# Altium schematic sheet LM25148_eval_BRD.SchDoc
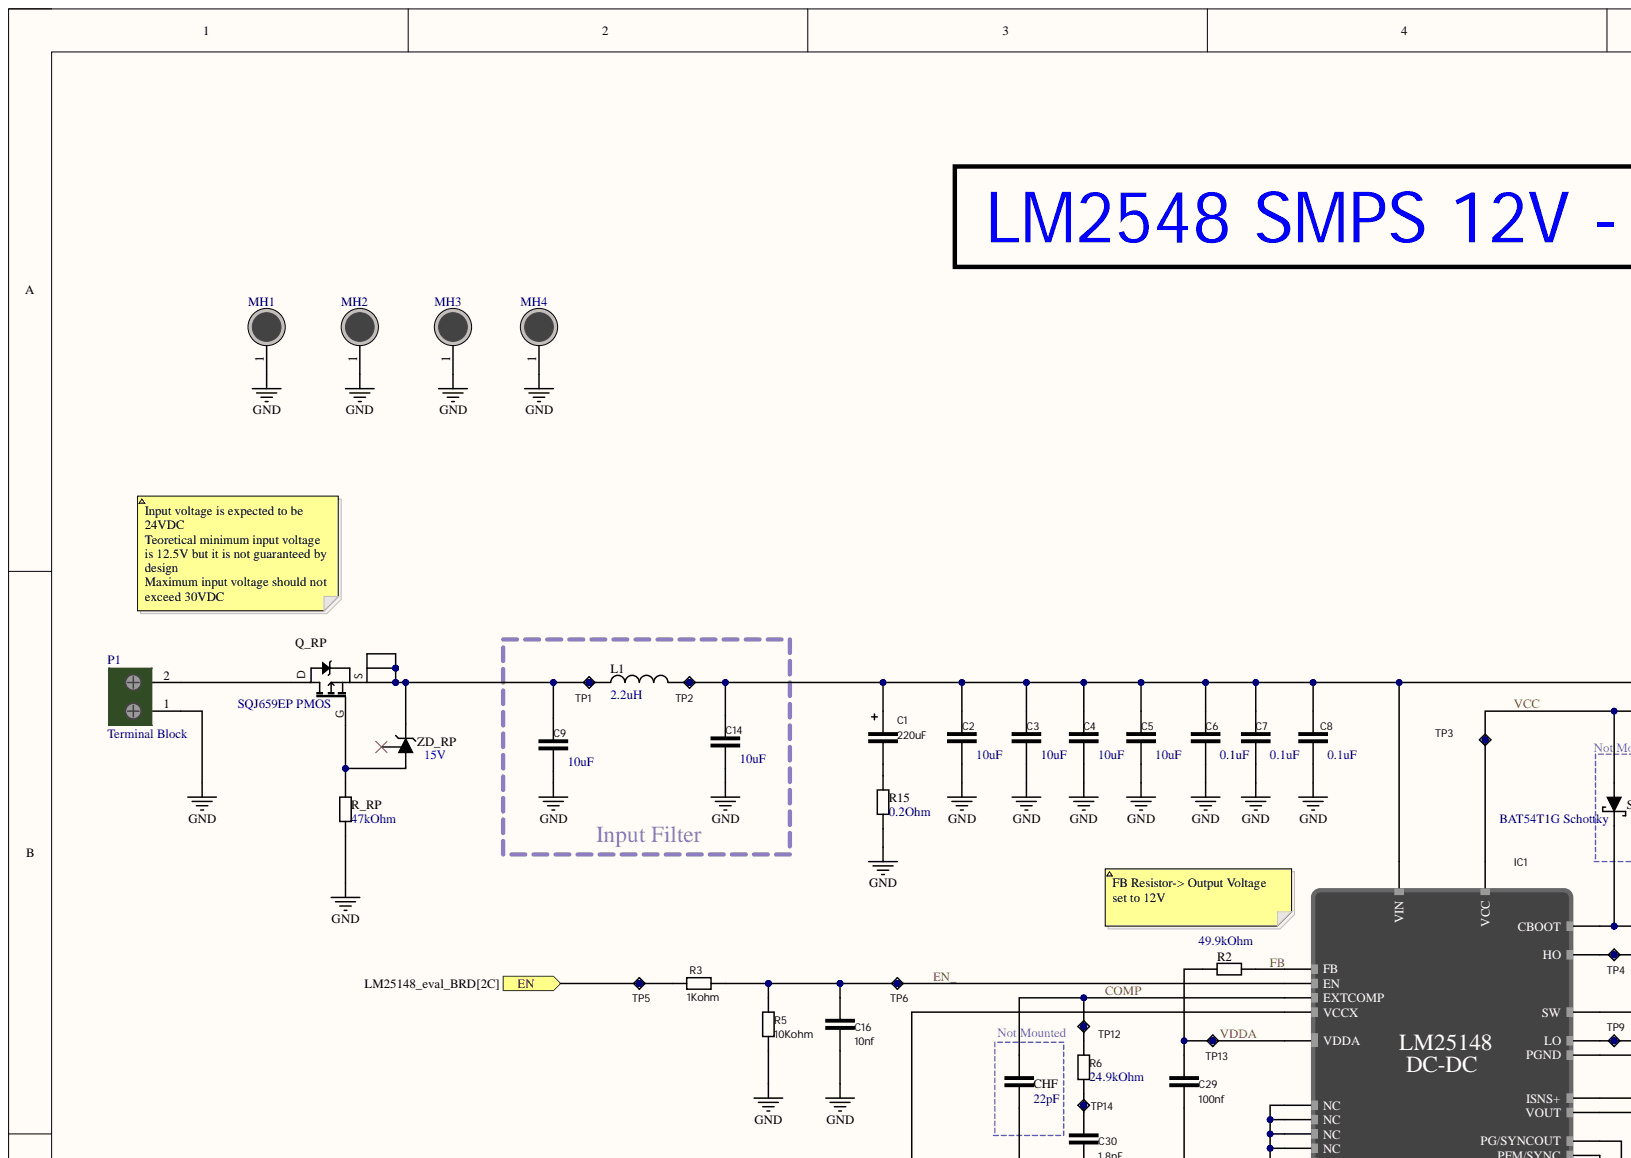
[diagram p0: LM25148_eval_BRD.SchDoc, top left]
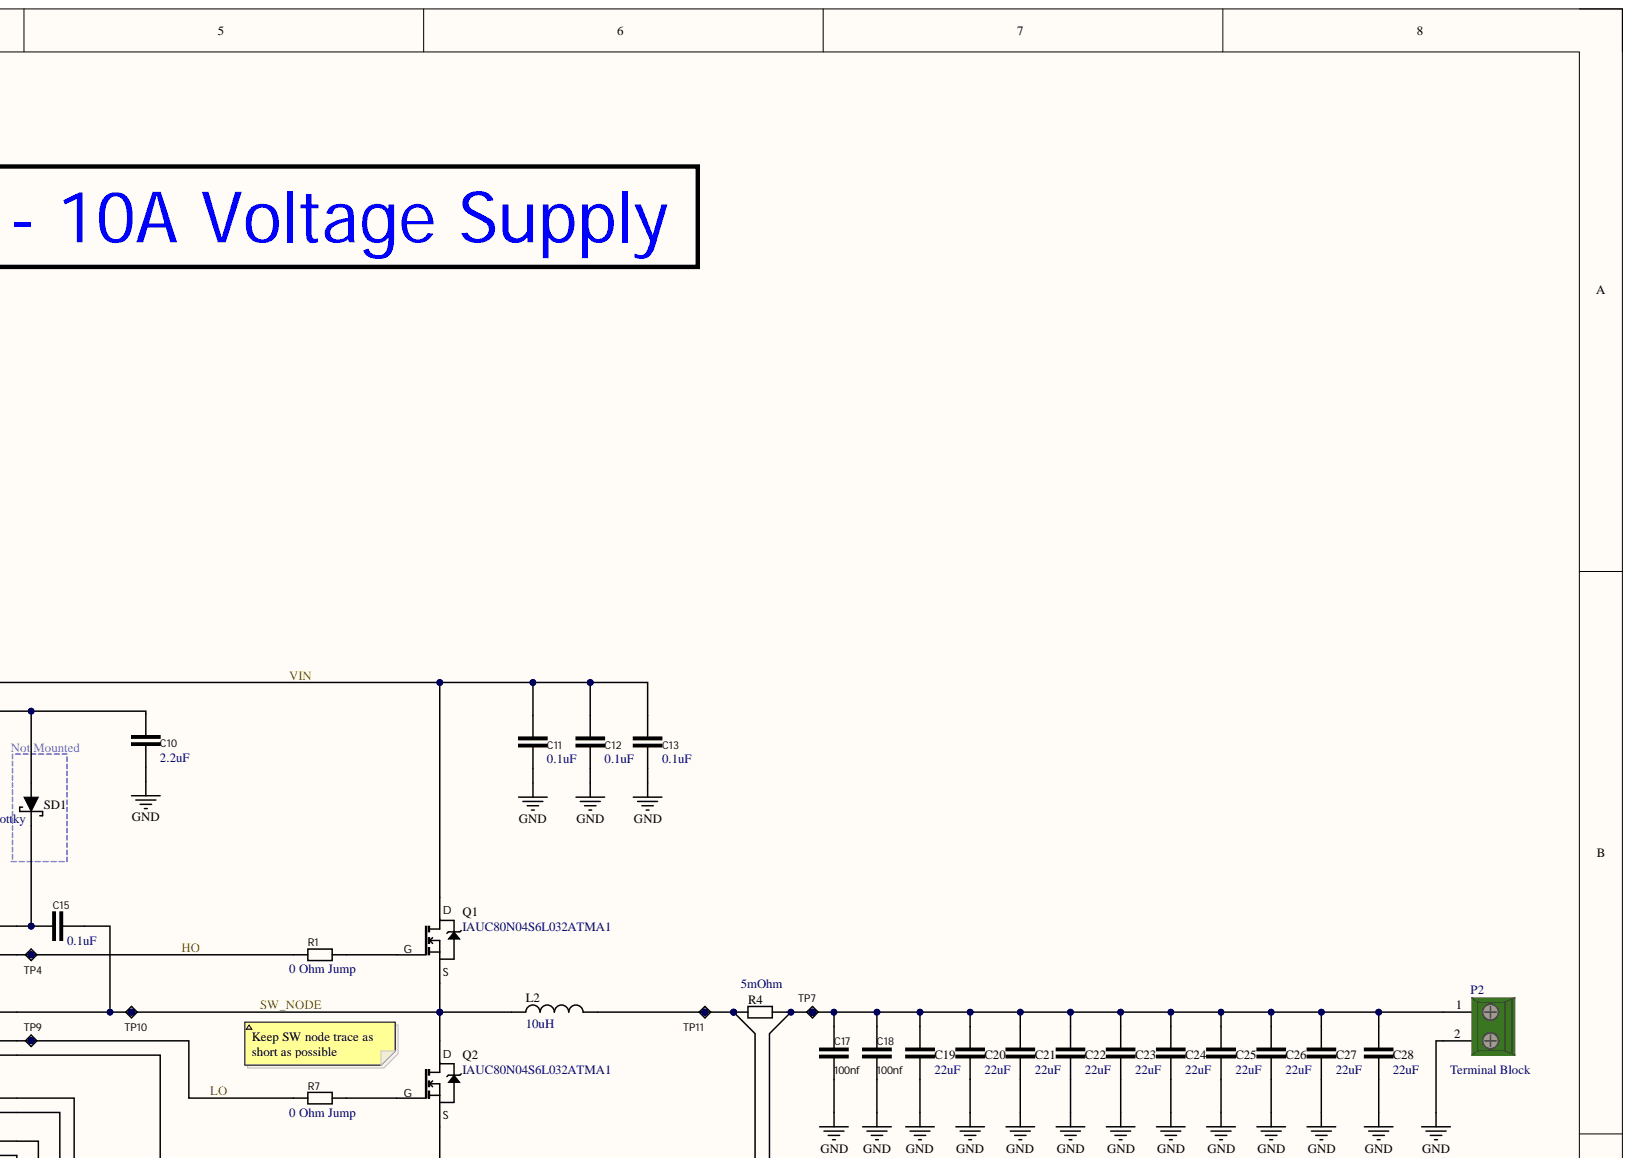
[diagram p1: LM25148_eval_BRD.SchDoc, top right]
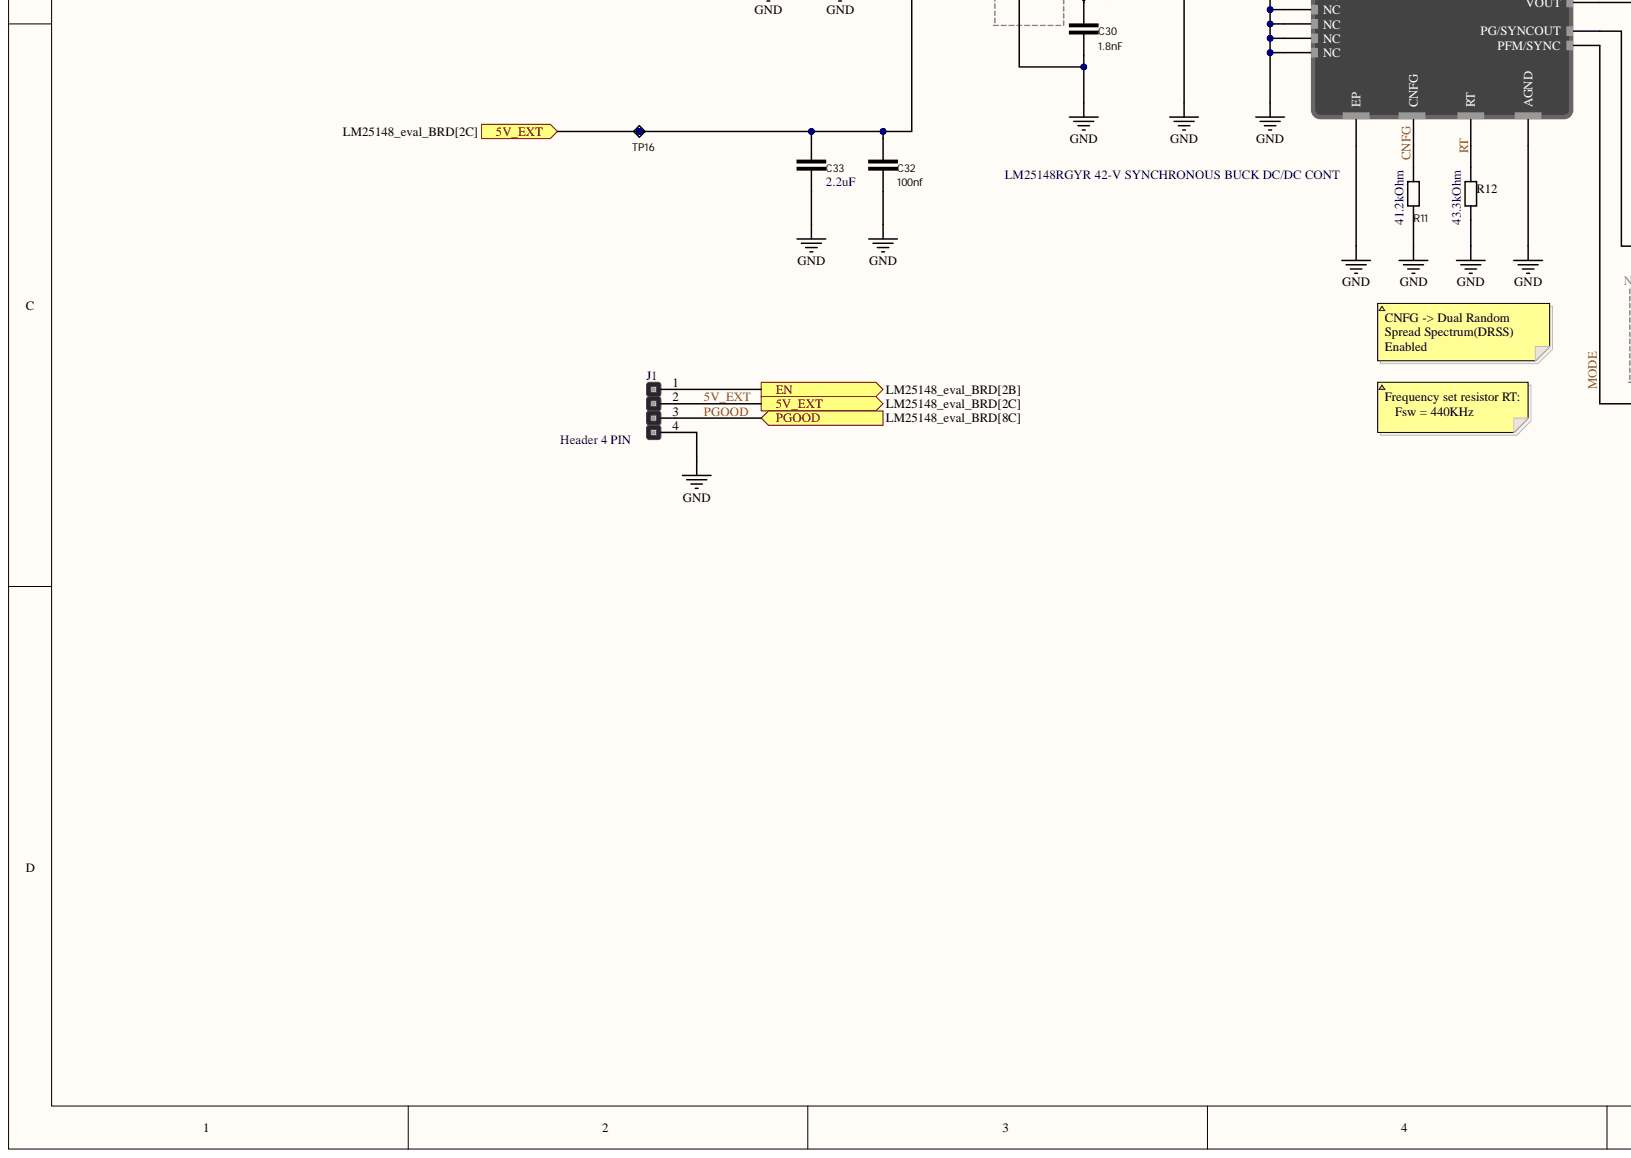
[diagram p2: LM25148_eval_BRD.SchDoc, bottom left]
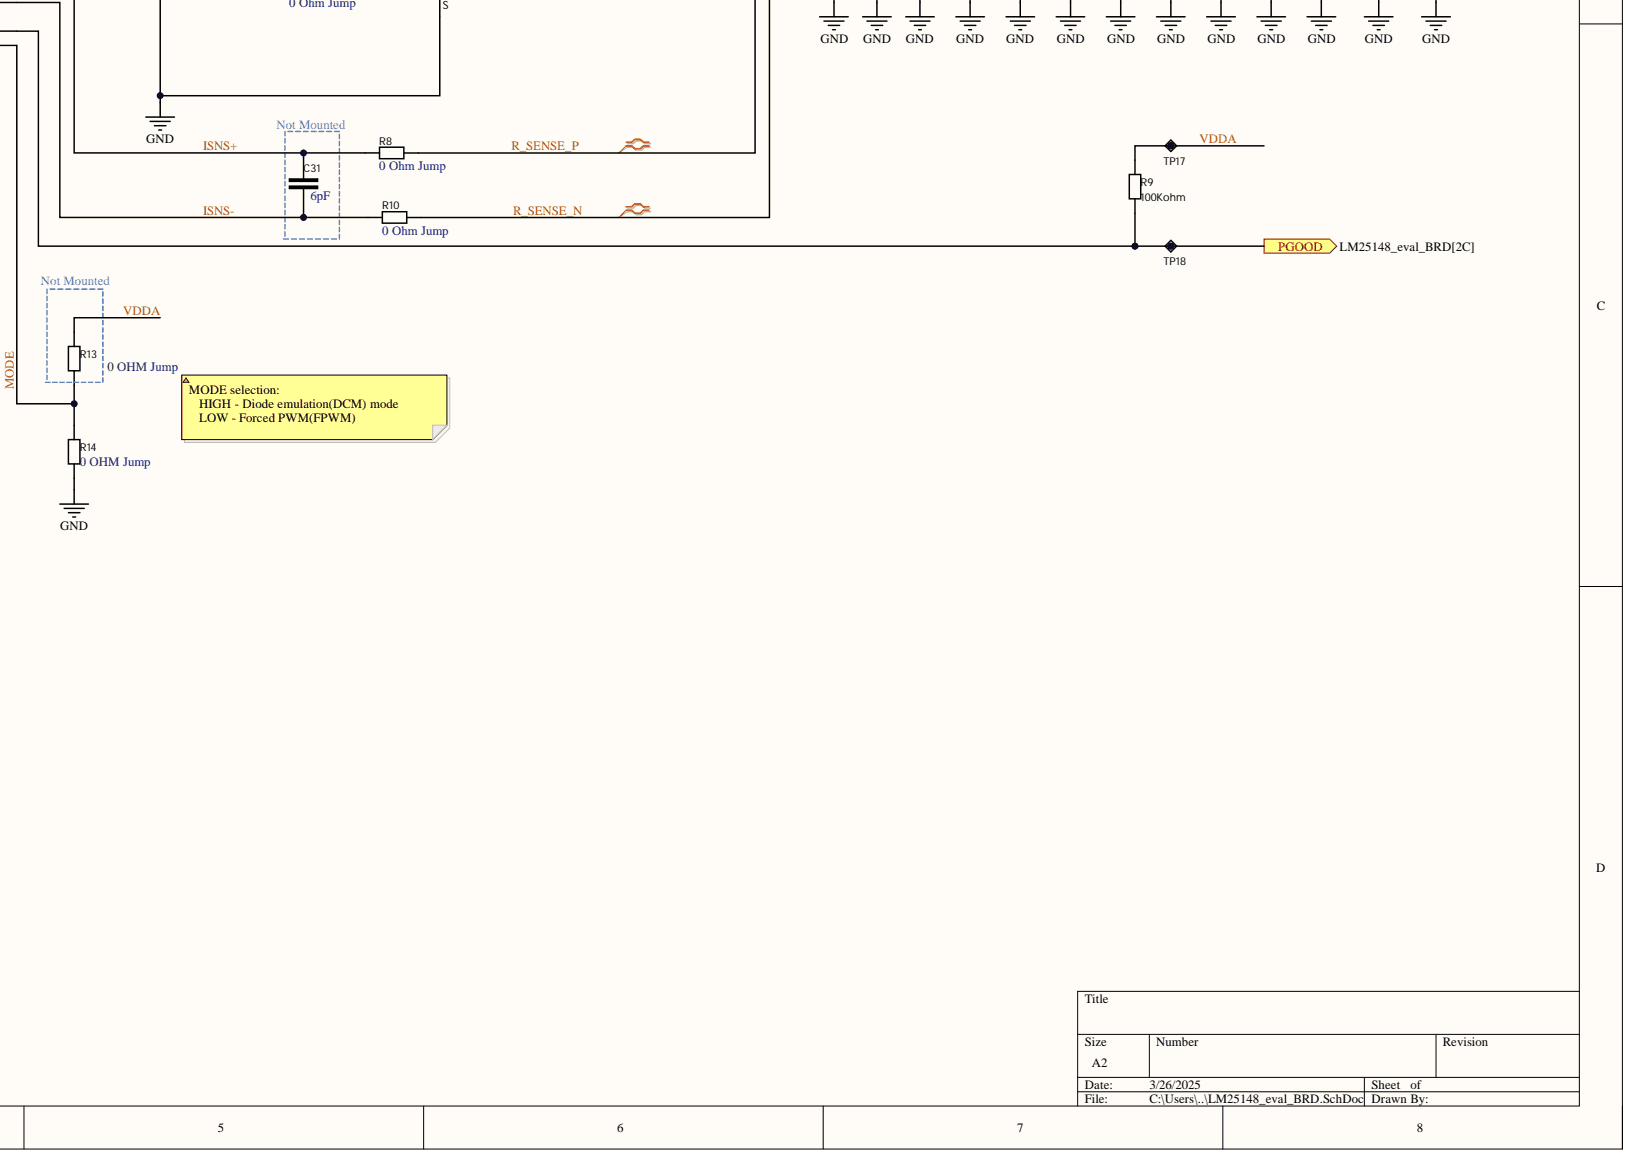
[diagram p3: LM25148_eval_BRD.SchDoc, bottom right]

## Parts
C1  220uF  [CAPAE109103X103M]
C2  10uF  [CAP_1206]
C3  10uF  [CAP_1206]
C4  10uF  [CAP_1206]
C5  10uF  [CAP_1206]
C6  0.1uF  [CAP_0603]
C7  0.1uF  [CAP_0603]
C8  0.1uF  [CAP_0603]
C9  10uF  [CAP_1206]
C10  2.2uF  [CAP_0603]
C11  0.1uF  [CAP_0603]
C12  0.1uF  [CAP_0603]
C13  0.1uF  [CAP_0603]
C14  10uF  [CAP_1206]
C15  0.1uF  [CAP_0603]
C16  10nf  [CAP_0603]
C17  100nf  [CAP_0603]
C18  100nf  [CAP_0603]
C19  22uF  [CAP_1210]
C20  22uF  [CAP_1210]
C21  22uF  [CAP_1210]
C22  22uF  [CAP_1210]
C23  22uF  [CAP_1210]
C24  22uF  [CAP_1210]
C25  22uF  [CAP_1210]
C26  22uF  [CAP_1210]
C27  22uF  [CAP_1210]
C28  22uF  [CAP_1210]
C29  100nf  [CAP_0603]
C30  1.8nF  [CAP_0603]
C31  6pF  [CAP_0402]
C32  100nf  [CAP_0603]
C33  2.2uF  [CAP_0603]
CHF  22pF  [CAP_0603]
IC1  LM25148RGYR 42-V SYNCHRONOUS BUCK DC/DC CONT  [VQFN25_RGY_TEX]
J1  Header 4 PIN  [HEADER_4PIN]
L1  2.2uH  [IHLP-3232DZ-51]
L2  10uH  [INDP135126X65N]
MH1  Mounting Hole  [HOLE-3mm]  (value not drawn)
MH2  Mounting Hole  [HOLE-3mm]  (value not drawn)
MH3  Mounting Hole  [HOLE-3mm]  (value not drawn)
MH4  Mounting Hole  [HOLE-3mm]  (value not drawn)
P1  Terminal Block  [TERM_BLOCK 5mm 2PIN]
P2  Terminal Block  [TERM_BLOCK 5mm 2PIN]
Q1  IAUC80N04S6L032ATMA1  [PG-TDSON-8-33]
Q2  IAUC80N04S6L032ATMA1  [PG-TDSON-8-33]
Q_RP  SQJ659EP PMOS  [PowerPAK SO-8L SINGLE]
R1  0 Ohm Jump  [RES_0402]
R2  49.9kOhm  [RES_0603]
R3  1Kohm  [RES_0603]
R4  5mOhm  [RES_1020_WIDE]
R5  10Kohm  [RES_0603]
R6  24.9kOhm  [RES_0603]
R7  0 Ohm Jump  [RES_0402]
R8  0 Ohm Jump  [RES_0402]
R9  100Kohm  [RES_0603]
R10  0 Ohm Jump  [RES_0402]
R11  41.2kOhm  [RES_0603]
R12  43.3kOhm  [RES_0603]
R13  0 OHM Jump  [RES_0603]
R14  0 OHM Jump  [RES_0603]
R15  0.2Ohm  [RES_1206]
R_RP  47kOhm  [RES_0603]
SD1  BAT54T1G Schottky  [SODFL371X135-2N]
TP1  Test point  [TestPoint]  (value not drawn)
TP2  Test point  [TestPoint]  (value not drawn)
TP3  Test point  [TestPoint]  (value not drawn)
TP4  Test point  [TestPoint]  (value not drawn)
TP5  Test point  [TestPoint]  (value not drawn)
TP6  Test point  [TestPoint]  (value not drawn)
TP7  Test point  [TestPoint]  (value not drawn)
TP9  Test point  [TestPoint]  (value not drawn)
TP10  Test point  [TestPoint]  (value not drawn)
TP11  Test point  [TestPoint]  (value not drawn)
TP12  Test point  [TestPoint]  (value not drawn)
TP13  Test point  [TestPoint]  (value not drawn)
TP14  Test point  [TestPoint]  (value not drawn)
TP16  Test point  [TestPoint]  (value not drawn)
TP17  Test point  [TestPoint]  (value not drawn)
TP18  Test point  [TestPoint]  (value not drawn)
ZD_RP  DZ23C15-E3-08 Zener Diode  [SOT95P245X96-3N]  (value not drawn)

## Ports
5V_EXT, EN, PGOOD

## Nets
GND: C2.1 C3.2 C4.2 C5.2 C6.2 C7.2 C8.1 C9.1 C10.1 C11.1 C12.1 C13.2 C14.1 C16.1 C17.1 C18.1 C19.2 C20.2 C21.1 C22.2 C23.1 C24.2 C25.1 C26.1 C27.2 C28.1 C29.1 C30.1 C32.1 C33.2 CHF.1 IC1.1(NC) IC1.2(NC) IC1.7(AGND) IC1.10(PGND) IC1.22(NC) IC1.23(NC) IC1.24(NC) IC1.25(EP) J1.4 MH1.1 MH2.1 MH3.1 MH4.1 P1.1 P2.2 Q2.S R5.1 R11.1 R12.1 R14.1 R15.1 R_RP.1
5V_EXT: C32.2 C33.1 IC1.16(VCCX) J1.2 TP16.1 ; port 5V_EXT
CNFG: IC1.3(CNFG) R11.2
COMP: CHF.2 IC1.5(EXTCOMP) R6.2 TP12.1
EN: J1.1 R3.1 TP5.1 ; port EN
EN_: C16.2 IC1.19(EN) R3.2 R5.2 TP6.1
FB: IC1.6(FB) R2.2
HO: IC1.13(HO) R1.1 TP4.1
ISNS+: C31.2 IC1.20(ISNS+) R8.1
ISNS-: C31.1 IC1.21(VOUT) R10.1
LO: IC1.11(LO) R7.1 TP9.1
MODE: IC1.18(PFM/SYNC) R13.1 R14.2
PGOOD: IC1.17(PG/SYNCOUT) J1.3 R9.1 TP18.1 ; port PGOOD
R_SENSE_N: C17.2 C18.2 C19.1 C20.1 C21.2 C22.1 C23.2 C24.1 C25.2 C26.2 C27.1 C28.2 P2.1 R4.2 R10.2 TP7.1
R_SENSE_P: L2.2 R4.1 R8.2 TP11.1
RT: IC1.4(RT) R12.2
SW_NODE: C15.1 IC1.14(SW) L2.1 Q1.S Q2.D TP10.1
VCC: C10.2 IC1.9(VCC) SD1.1 TP3.1
VDDA: C29.2 IC1.8(VDDA) R2.1 R9.2 R13.2 TP13.1 TP17.1
VIN: C1.2 C2.2 C3.1 C4.1 C5.1 C6.1 C7.1 C8.2 C11.2 C12.2 C13.1 C14.2 IC1.12(VIN) L1.2 Q1.D TP2.1
NetC1_1: C1.1 R15.2
NetC9_2: C9.2 L1.1 Q_RP.S TP1.1 ZD_RP.3
NetC15_2: C15.2 IC1.15(CBOOT) SD1.2
NetC30_2: C30.2 R6.1 TP14.1
NetP1_2: P1.2 Q_RP.D
NetQ1_G: Q1.G R1.2
NetQ2_G: Q2.G R7.2
NetQ_RP_G: Q_RP.G R_RP.2 ZD_RP.1

Not connected: ZD_RP 2

## Library data of the parts
C1 = C000033: 220 µF 35 V Aluminum - Polymer Capacitors Radial, Can - SMD 23mOhm 1000 Hrs @ 150°C; capaticanse: 220uF; Component Kind: Standard; ComponentLink1Description: digikey; ComponentLink1URL: https://www.digikey.com/en/products/detail/kemet/A785MN227M1VLAS023/22003773?s=N4IgTCBcDaIIIHYAcBWAsgOTGBaCMAagDJwDKADGAMwgC6AvkA; DigiKey part number: 399-A785MN227M1VLAS023CT-ND; Library Name: CapacitorsIntegrated.IntLib
C2-C5 C9 C14 = C000017: CAP CER 10UF 50V X7R 1206; capacitance: 10uF; Component Kind: Standard; ComponentLink1Description: DigiKey; ComponentLink1URL: https://www.digikey.com/en/products/detail/samsung-electro-mechanics/CL31B106KBHNNNE/5961251; DigiKey Part Number: 1276-6767-1-ND; Library Name: CapacitorsIntegrated.IntLib
C6-C8 C11-C13 C15 = C000018: CAP CER 0.1UF 50V X7R 0603; capacitance: 0.1uF; Component Kind: Standard; ComponentLink1Description: DigiKey; ComponentLink1URL: https://www.digikey.com/en/products/detail/samsung-electro-mechanics/CL10B104KB8NNNC/3886658; DigiKey Part Number: 1276-1000-1-ND; Library Name: CapacitorsIntegrated.IntLib
C10 C33 = C000014: CAP CER 2.2UF 16V X7S 0603; capaticanse: 2.2uF; Component Kind: Standard; ComponentLink1Description: Digikey; ComponentLink1URL: https://www.digikey.com/en/products/detail/murata-electronics/GRM188C71C225KE11D/4905311; Digikey Part Number: 490-12731-1-ND; Library Name: CapacitorsIntegrated.IntLib
C16 = C000005: CAP CER 10nF 0603 25V X7R; capaticanse: 10nf; Component Kind: Standard; ComponentLink1Description: digikey; ComponentLink1URL: https://www.digikey.com/en/products/detail/kyocera-avx/06033C103MAT2A/1599370; Library Name: CapacitorsIntegrated.IntLib
C17 C18 C29 C32 = C000007: CAP CER 100nF 0603 25V X7R; capaticanse: 100nf; Component Kind: Standard; ComponentLink1Description: digikey; ComponentLink1URL: https://www.digikey.com/en/products/detail/kyocera-avx/06033C104K4T2A/8019250; Library Name: CapacitorsIntegrated.IntLib
C19-C28 = C000032: 22 µF ±10% 25V Ceramic Capacitor X7R 1210 (3225 Metric); capacitanse: 22uF; Component Kind: Standard; ComponentLink1Description: DigiKey; ComponentLink1URL: https://www.digikey.com/en/products/detail/samsung-electro-mechanics/CL32B226KAJNNNE/3889050; DigiKey Part Number: 1276-3392-1-ND; Library Name: CapacitorsIntegrated.IntLib
C30 = C000027: 1800 pF ±10% 25V Ceramic Capacitor X7R 0603 (1608 Metric); capaticanse: 1.8nF; Component Kind: Standard; ComponentLink1Description: digikey; ComponentLink1URL: https://www.digikey.com/en/products/detail/kemet/C0603C182K3RAC7867/2200107; DigiKey Part Number: 399-15370-1-ND; Library Name: CapacitorsIntegrated.IntLib
C31 = C000016: CAP CER 6PF 25V C0G/NP0 0402; capacitanse: 6pF; Component Kind: Standard; ComponentLink1Description: DigiKey; ComponentLink1URL: https://www.digikey.com/en/products/detail/kemet/CBR04C609D3GAC/18137865?s=N4IgjCBcpgLFoDGUBmBDANgZwKYBoQB7KAbXAFYBOAJlgHYQBdAgBwBcoQBlNgJwEsAdgHMQAXwJgAbAhDJI6bPiKkQAZkphyADiasOkbnyGiJIagAZYlWfMW4CxSGSkACFgDE9IdpwCqgvxsAPIoALI4aFgArrw44gQAtNS2UHzRyk5k5ExieUA; DigiKey Part Number: 399-CBR04C609D3GACCT-ND; Library Name: CapacitorsIntegrated.IntLib
CHF = C000024: 22 pF ±10% 25V Ceramic Capacitor X7R 0603 (1608 Metric); capacitance: 22pF; Component Kind: Standard; ComponentLink1Description: DigiKey; ComponentLink1URL: https://www.digikey.com/en/products/detail/kemet/C0603C220K3RACAUTO/16524857; DigiKey Part Number: 399-C0603C220K3RACAUTOCT-ND; Library Name: CapacitorsIntegrated.IntLib
IC1 = LM25148RGYR: Buck Regulator Positive Output Step-Down DC-DC Controller IC 24-VQFN (5.5x3.5); Component Kind: Standard; ComponentLink1Description: DigiKey; ComponentLink1URL: https://www.digikey.com/en/products/detail/texas-instruments/LM25148RGYR/15857124; ComponentLink2Description: Mouser; ComponentLink2URL: https://eu.mouser.com/ProductDetail/Texas-Instruments/LM25148RGYR?qs=doiCPypUmgEViljGB5jAZw%3D%3D; DigiKey Part Number: 296-LM25148RGYRCT-ND; Library Name: IntegratedCircuits.IntLib; Mouser Part Number: 595-LM25148RGYR
J1 = 00000004: Component Kind: Standard; Description: Header 4 PIN; Library Name: ElectroMechanical.IntLib
L1 = L000015: FIXED IND 2.2UH 11.5A 12.5 MOHM; case: 3232; Component Kind: Standard; ComponentLink1Description: DigiKey; ComponentLink1URL: https://www.digikey.com/en/products/detail/vishay-dale/IHLP3232DZER2R2M5A/4070222; ComponentLink2Description: Mouser; ComponentLink2URL: https://eu.mouser.com/ProductDetail/Vishay-Dale/IHLP3232DZER2R2M5A?qs=tDDpbAIEejr3%252BeiaEh99%252Bg%3D%3D; DigiKey Part Number: 541-IHLP3232DZER2R2M5ACT-ND; inductance: 2.2uH; Library Name: InductorIntegrated.IntLib; Mouser Part Number: 70-IHLP3232DZR2R2M5A
L2 = L000016: FIXED IND 10UH 11A 15.8 MOHM SMD; case: 13.5x12.6; Component Kind: Standard; ComponentLink1Description: DigiKey; ComponentLink1URL: https://www.digikey.com/en/products/detail/pulse-electronics/PA4343-103ANLT/7943151?s=N4IgTCBcDaIAoEEAsBmVA6AjABhQgcgDIAqIAugL5A; ComponentLink2Description: Mouser; ComponentLink2URL: https://eu.mouser.com/ProductDetail/Pulse-Electronics/PA4343.103ANLT?qs=chTDxNqvsym%252BWuIQdh7qfw%3D%3D; DigiKey Part Number: 553-4029-1-ND; inductance: 10uH; Library Name: InductorIntegrated.IntLib; Mouser Part Number: 673-PA4343.103ANLT
MH1-MH4 = 00000006: Mounting Hole 3mm; Component Kind: Standard; Library Name: ElectroMechanical.IntLib
P1 P2 = 00000001: Terminal block 90 deg 5mm 2PIN; Component Kind: Standard; ComponentLink1Description: DigiKey; ComponentLink1URL: https://www.digikey.com/en/products/detail/adam-tech/EB20-02-C/9831508; DigiKey part number: 2057-EB20-02-C-ND; Library Name: ElectroMechanical.IntLib
Q1 Q2 = 00000008: N-Channel 40 V 80A (Tc) 50W (Tc) Surface Mount PG-TDSON-8; Component Kind: Standard; ComponentLink1Description: DigiKey; ComponentLink1URL: https://www.digikey.com/en/products/detail/infineon-technologies/IAUC80N04S6L032ATMA1/13677609; ComponentLink2Description: Mouser; ComponentLink2URL: https://eu.mouser.com/ProductDetail/Infineon-Technologies/IAUC80N04S6L032ATMA1?qs=BJlw7L4Cy7%2F3D%2F1wP9Fszw%3D%3D; ComponentLink3Description: Footprint Drawing; ComponentLink3URL: https://www.infineon.com/cms/en/product/packages/PG-TDSON/PG-TDSON-8-33/; DigiKey Part Number: 448-IAUC80N04S6L032ATMA1CT-ND; Library Name: Miscellaneous_Lib1.IntLib; Mouser Part Number: 726-IAUC80N04S6L032A
Q_RP = 00000005: P-Channel 60 V 52A (Tc) 83W (Tc) Surface Mount PowerPAK® SO-8; Component Kind: Standard; ComponentLink1Description: DigiKey; ComponentLink1URL: https://www.digikey.com/en/products/detail/vishay-siliconix/SQJ459EP-T1-GE3/6708881; ComponentLink2Description: Mouser; ComponentLink2URL: https://eu.mouser.com/ProductDetail/Vishay-Siliconix/SQJ459EP-T2_BE3?qs=CiayqK2gdcKpg8h0vLRPjA%3D%3D; DigiKey Part Number: SQJ459EP-T1_GE3CT-ND; Library Name: Miscellaneous_Lib1.IntLib; Mouser Part Number: 78-SQJ459EP-T2_BE3
R1 R7 R8 R10 = R000008: RES SMD 0 OHM JUMPER 1/10W 0402; Component Kind: Standard; ComponentLink1Description: DigiKey; ComponentLink1URL: https://www.digikey.com/en/products/detail/panasonic-electronic-components/ERJ-2GE0R00X/146727; DigiKey Part Number: P0.0JCT-ND; Library Name: ResistorsIntegrated.IntLib; resistance: 0 Ohm Jump
R2 = R000025: 49.9 kOhms ±1% 0.1W, 1/10W Chip Resistor 0603 (1608 Metric) Moisture Resistant Thick Film; Component Kind: Standard; ComponentLink1Description: DigiKey; ComponentLink1URL: https://www.digikey.com/en/products/detail/yageo/RC0603FR-0749K9L/727265; DigiKey Part Number: 311-49.9KHRCT-ND; Library Name: ResistorsIntegrated.IntLib; resistance: 49.9kOhm
R3 = R000004: RES 1K OHM 1% 1/10W 0603; Component Kind: Standard; ComponentLink1Description: digikey; ComponentLink1URL: https://www.digikey.com/en/products/detail/vishay-beyschlag-draloric-bc-components/MCT06030C1001FP500/2607821; Library Name: ResistorsIntegrated.IntLib; resistance: 1Kohm
R4 = R000026: 5 mOhms ±1% 2W Chip Resistor Wide 2010 (5025 Metric), 1020 Anti-Sulfur, Automotive AEC-Q200, Current Sense, Moisture Resistant, Pulse Withstanding Metal Element; Component Kind: Standard; ComponentLink1Description: DigiKey; ComponentLink1URL: https://www.digikey.com/en/products/detail/vishay-dale/WSL10205L000FEA/9758314; DigiKey Part Number: 541-10046-1-ND; Library Name: ResistorsIntegrated.IntLib; resistance: 5mOhm
R5 = R000001: RES 10K OHM 1% 1/10W 0603; Component Kind: Standard; ComponentLink1Description: digikey; ComponentLink1URL: https://www.digikey.com/en/products/detail/vishay-beyschlag-draloric-bc-components/MCT06030C1002FP500/1850440; Library Name: ResistorsIntegrated.IntLib; resistance: 10Kohm
R6 = R000017: 24.9 kOhms ±1% 0.1W, 1/10W Chip Resistor 0603 (1608 Metric) Automotive AEC-Q200 Thick Film; Component Kind: Standard; ComponentLink1Description: DigiKey; ComponentLink1URL: https://www.digikey.com/en/products/detail/panasonic-electronic-components/ERJ-3EKF2492V/196236; DigiKey Part Number: P24.9KHCT-ND; Library Name: ResistorsIntegrated.IntLib; resistance: 24.9kOhm
R9 = R000006: RES 100K OHM 1% 1/10W 0603; Component Kind: Standard; ComponentLink1Description: digikey; ComponentLink1URL: https://www.digikey.com/en/products/detail/stackpole-electronics-inc/RMCF0603FG100K/1713543; Library Name: ResistorsIntegrated.IntLib; resistance: 100Kohm
R11 = R000015: 41.2 kOhms ±1% 0.1W, 1/10W Chip Resistor 0603 (1608 Metric) Automotive AEC-Q200 Thick Film; Component Kind: Standard; ComponentLink1Description: DigiKey; ComponentLink1URL: https://www.digikey.com/en/products/detail/panasonic-electronic-components/ERJ-3EKF4122V/196347; DigiKey Part Number: P41.2KHCT-ND; Library Name: ResistorsIntegrated.IntLib; resistance: 41.2kOhm
R12 = R000023: 49.3 kOhms ±0.1% 0.1W, 1/10W Chip Resistor 0603 (1608 Metric) Thin Film; Component Kind: Standard; ComponentLink1Description: DigiKey; ComponentLink1URL: https://www.digikey.com/en/products/detail/yageo/RT0603BRD0749K3L/5927964; DigiKey Part Number: 13-RT0603BRD0749K3LCT-ND; Library Name: ResistorsIntegrated.IntLib; resistance: 43.3kOhm
R13 R14 = R000007: RES 0 OHM JUMPER 1/10W 0603; Component Kind: Standard; ComponentLink1Description: DigiKey; ComponentLink1URL: https://www.digikey.com/en/products/detail/stackpole-electronics-inc/RMCF0603ZT0R00/1756908; Library Name: ResistorsIntegrated.IntLib; resistance: 0 OHM Jump; Supplier 1: Arrow Electronics; Supplier Part Number 1: RMCF0603ZT0R00
R15 = R000024: 200 mOhms ±1% 0.5W, 1/2W Chip Resistor 1206 (3216 Metric) Current Sense Thick Film; Component Kind: Standard; ComponentLink1Description: DigiKey; ComponentLink1URL: https://www.digikey.com/en/products/detail/stackpole-electronics-inc/CSR1206FKR200/1742391?s=N4IgTCBcDaIMIGUBKBGMAGAbAMQNJI3RAF0BfIA; DigiKey Part Number: CSR1206FKR200CT-ND; Library Name: ResistorsIntegrated.IntLib; resistance: 0.2Ohm
R_RP = R000010: 47 kOhms ±1% 0.1W, 1/10W Chip Resistor 0603 (1608 Metric) Moisture Resistant Thick Film; Component Kind: Standard; ComponentLink1Description: DigiKey; ComponentLink1URL: https://www.digikey.com/en/products/detail/yageo/RC0603FR-0747KL/727253; DigiKey Part Number: 311-47.0KHRCT-ND; Library Name: ResistorsIntegrated.IntLib; resistance: 47kOhm
SD1 = 00000009: Diode 30 V 200mA Surface Mount SOD-123; Component Kind: Standard; ComponentLink1Description: DigiKey; ComponentLink1URL: https://www.digikey.com/en/products/detail/onsemi/BAT54T1G/918319; DigiKey Part Number: BAT54T1GOSCT-ND; Library Name: Miscellaneous_Lib1.IntLib
TP1-TP7 TP9-TP14 TP16-TP18 = TP002: Test point 1mm; Component Kind: Standard; Library Name: IntegratedCircuits.IntLib
ZD_RP = 00000006: Zener Diode Array 1 Pair Common Cathode 15 V 300 mW ±5% SOT-23-3; Component Kind: Standard; ComponentLink1Description: DigiKey; ComponentLink1URL: https://www.digikey.com/en/products/detail/vishay-general-semiconductor-diodes-division/DZ23C15-E3-08/4831460; DigiKey Part Number: 112-DZ23C15-E3-08CT-ND; Library Name: Miscellaneous_Lib1.IntLib
